# Altium schematic sheet Power.SchDoc
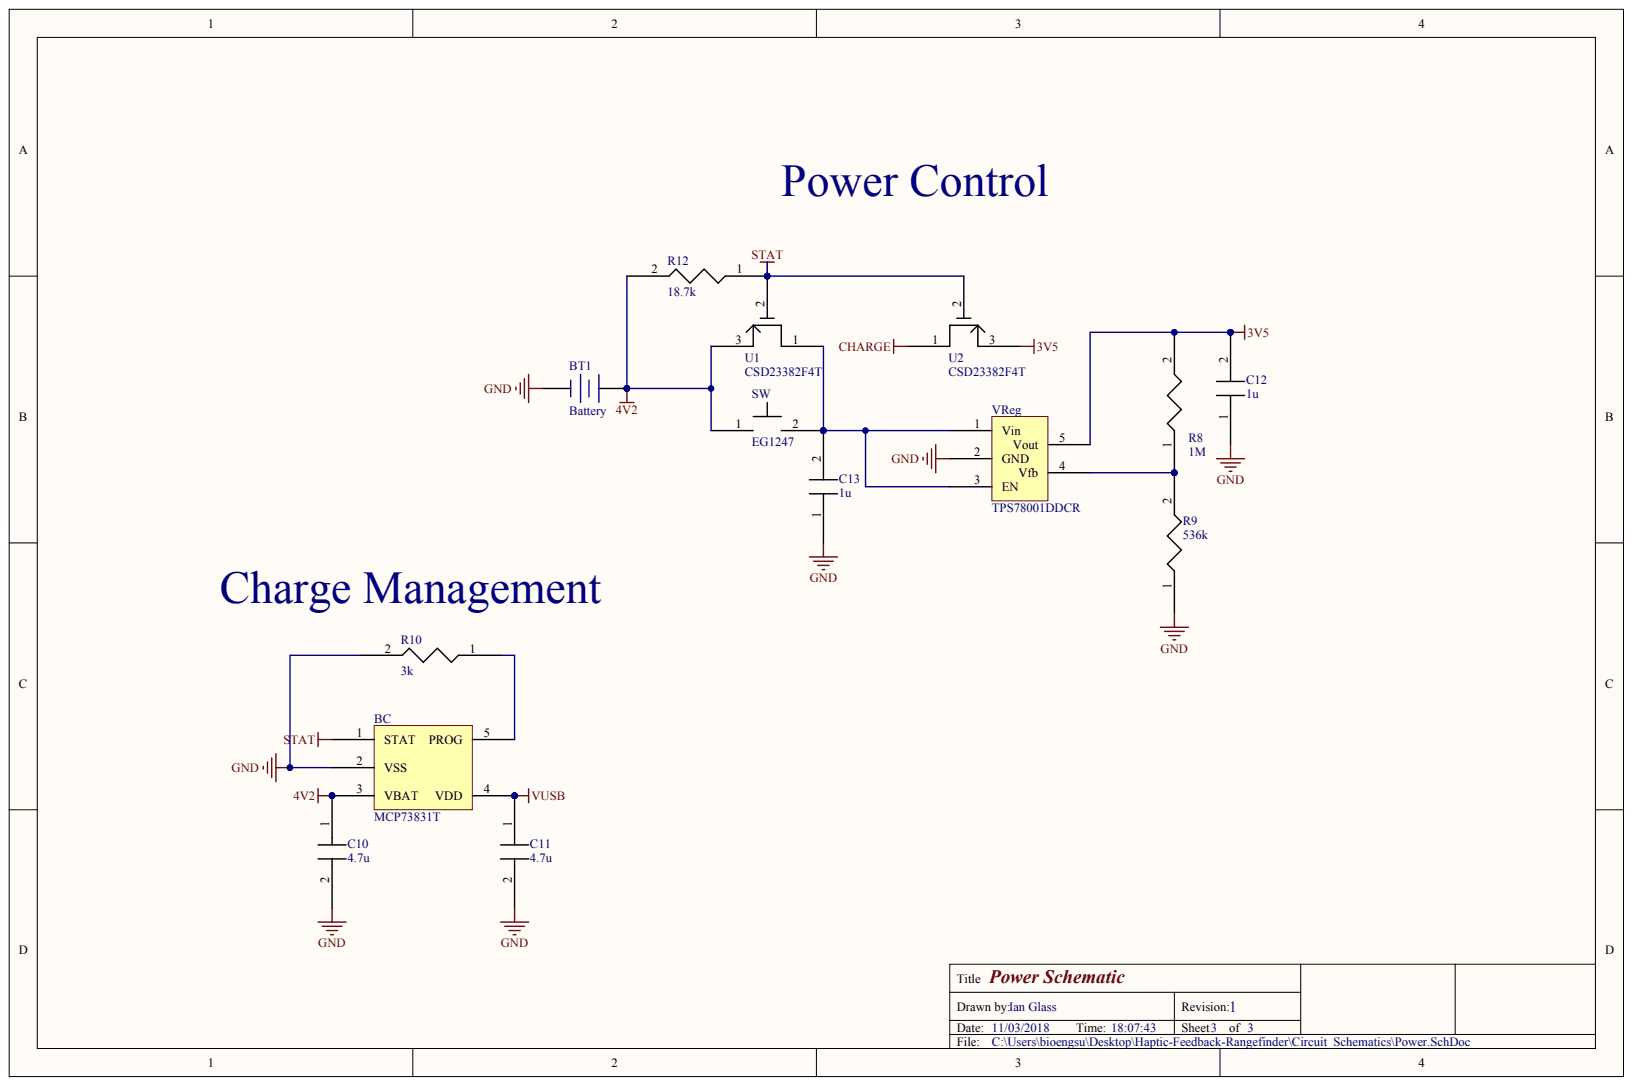
[diagram p0: Power.SchDoc]

## Parts
BC  MCP73831T
BT1  Battery
C10  4.7u
C11  4.7u
C12  1u
C13  1u
R8  1M
R9  536k
R10  3k
R12  18.7k
SW  EG1247
U1  CSD23382F4T
U2  CSD23382F4T
VReg  TPS78001DDCR

## Nets
3V5: C12.2 R8.2 U2.3 VReg.5(Vout)
4V2: BC.3(VBAT) BT1.1 C10.1 R12.2 SW.1 U1.3
CHARGE: U2.1
GND: BC.2(VSS) BT1.2 C10.2 C11.2 C12.1 C13.1 R9.1 R10.2 VReg.2(GND)
STAT: BC.1(STAT) R12.1 U1.2 U2.2
VUSB: BC.4(VDD) C11.1
NetBC_5: BC.5(PROG) R10.1
NetC13_2: C13.2 SW.2 U1.1 VReg.1(Vin) VReg.3(EN)
NetR8_1: R8.1 R9.2 VReg.4(Vfb)

## Library data of the parts
BC BT1 C10-C13 R8-R10 R12 SW U1 U2 VReg = (no symbol name)
  BT1: Published: 24-Mar-1999; LatestRevisionDate: 17-Jul-2002; LatestRevisionNote: Re-released for DXP Platform.; PackageReference: BAT-2; PackageDescription: Battery; 2 Leads; Publisher: Altium Limited
